# Altium schematic sheet STM32H750XB_POWER.SchDoc
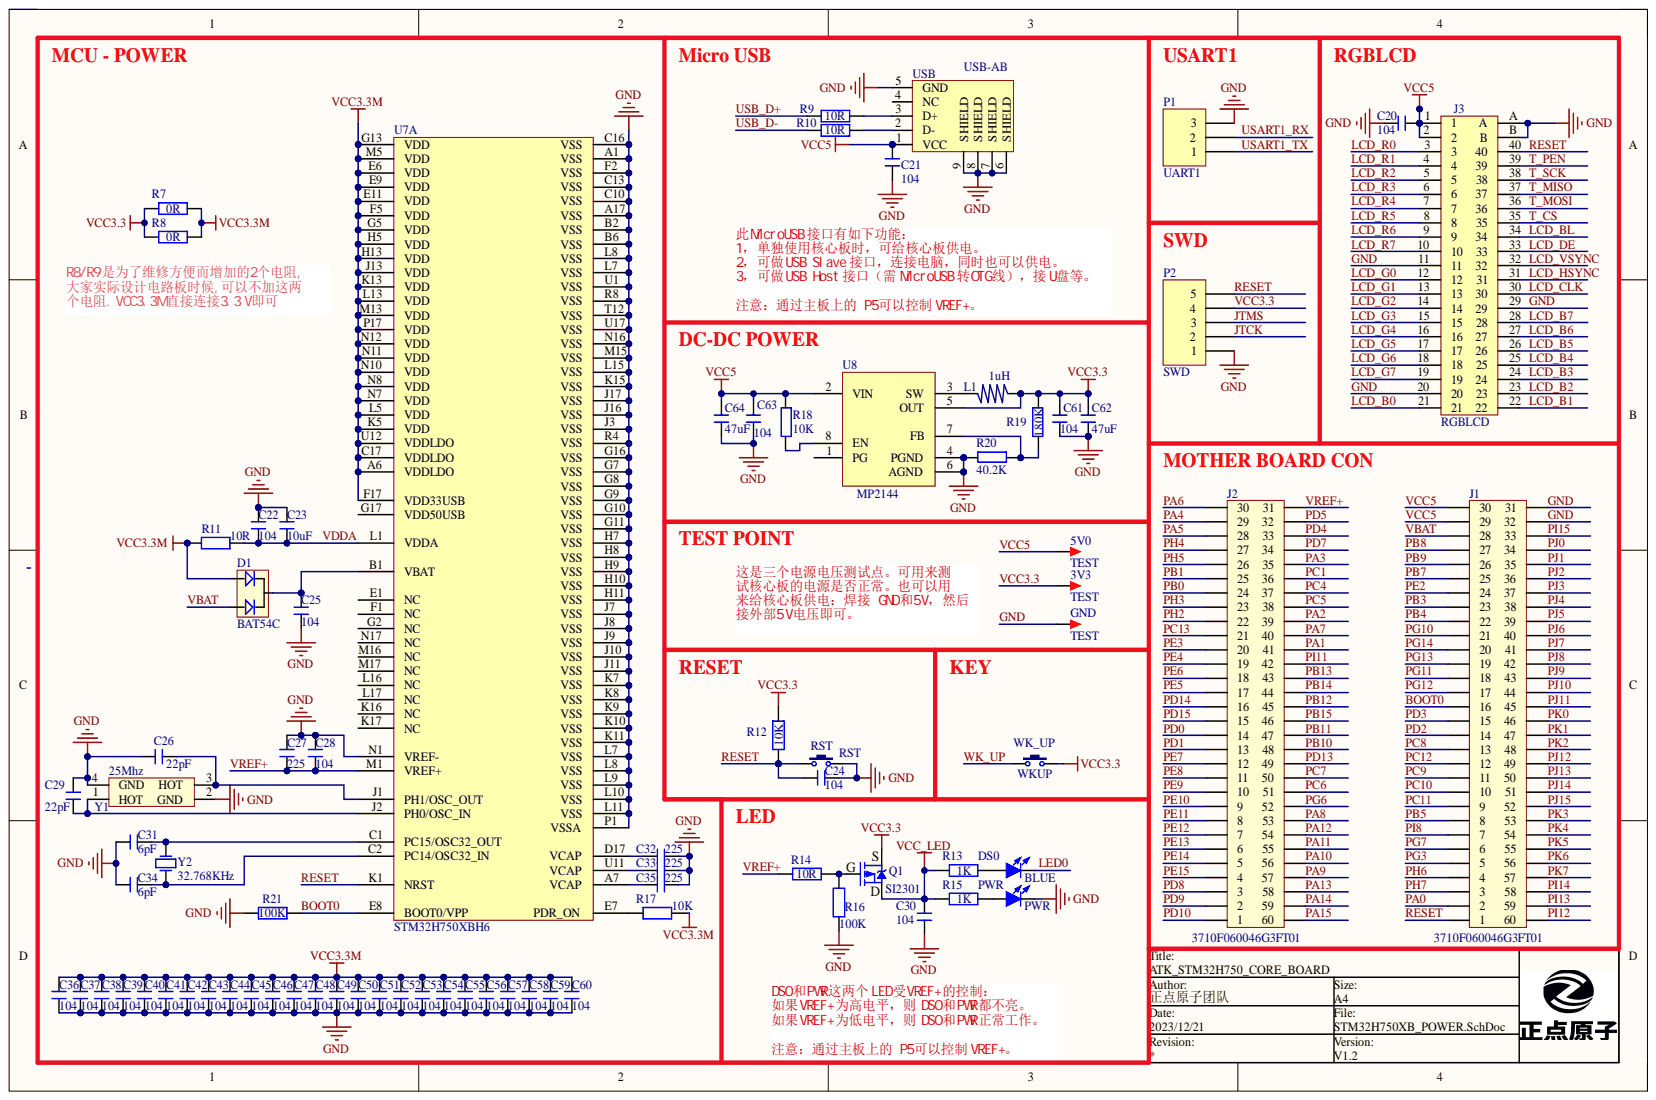
[diagram p0: STM32H750XB_POWER.SchDoc]

## Parts
3V3  TEST  [TEST 1]
5V0  TEST  [TEST 1]
C20  104  [C 0402]
C21  104  [C 0402]
C22  104  [C 0402]
C23  10uF  [C 0603]
C24  104  [C 0402]
C25  104  [C 0402]
C26  22pF  [C 0402]
C27  225  [C 0402]
C28  104  [C 0402]
C29  22pF  [C 0402]
C30  104  [C 0402]
C31  6pF  [C 0402]
C32  225  [C 0402]
C33  225  [C 0402]
C34  6pF  [C 0402]
C35  225  [C 0402]
C36  104  [C 0402]
C37  104  [C 0402]
C38  104  [C 0402]
C39  104  [C 0402]
C40  104  [C 0402]
C41  104  [C 0402]
C42  104  [C 0402]
C43  104  [C 0402]
C44  104  [C 0402]
C45  104  [C 0402]
C46  104  [C 0402]
C47  104  [C 0402]
C48  104  [C 0402]
C49  104  [C 0402]
C50  104  [C 0402]
C51  104  [C 0402]
C52  104  [C 0402]
C53  104  [C 0402]
C54  104  [C 0402]
C55  104  [C 0402]
C56  104  [C 0402]
C57  104  [C 0402]
C58  104  [C 0402]
C59  104  [C 0402]
C60  104  [C 0402]
C61  104  [C 0402]
C62  47uF  [C 0805]
C63  104  [C 0402]
C64  47uF  [C 0805]
D1  BAT54C  [SOT23-3L]
DS0  BLUE  [LED 0603B]
GND  TEST  [TEST 1]
J1  3710F060046G3FT01  [3710F060046G3FT01]
J2  3710F060046G3FT01  [3710F060046G3FT01]
J3  RGBLCD  [FPC0.5-TOP40P]
L1  1uH  [NR3015]
P1  UART1  [HDR1X3]
P2  SWD  [HDR1X5]
PWR  PWR  [LED 0603B]
Q1  SI2301  [SOT23-3N]
R7  0R  [R 0402]
R8  0R  [R 0402]
R9  10R  [R 0402]
R10  10R  [R 0402]
R11  10R  [R 0402]
R12  10K  [R 0402]
R13  1K  [R 0402]
R14  10R  [R 0402]
R15  1K  [R 0402]
R16  100K  [R 0402]
R17  10K  [R 0402]
R18  10K  [R 0402]
R19  180K  [R 0402]
R20  40.2K  [R 0402]
R21  100K  [R 0402]
RST  RST  [KEY-SOP324225]
U7  STM32H750XBH6  [BGA240+25]  (part A)
U8  MP2144  [SOT23-8L]
USB  USB-AB  [USB_AB - 5S - B]
WKUP  WK_UP  [KEY-SOP324225]
Y1  25Mhz  [XTAL-3225]
Y2  32.768KHz  [XTAL-3215]

## Nets
VCC3.3M: C36.2 C37.2 C38.2 C39.2 C40.2 C41.2 C42.2 C43.2 C44.2 C45.2 C46.2 C47.2 C48.2 C49.2 C50.2 C51.2 C52.2 C53.2 C54.2 C55.2 C56.2 C57.2 C58.2 C59.2 C60.2 D1.1 R7.2 R8.2 R11.1 R17.2 U7.A6(VDDLDO) U7.C17(VDDLDO) U7.E6(VDD) U7.E9(VDD) U7.E11(VDD) U7.F5(VDD) U7.F17(VDD33USB) U7.G5(VDD) U7.G13(VDD) U7.H5(VDD) U7.H13(VDD) U7.J13(VDD) U7.K5(VDD) U7.K13(VDD) U7.L5(VDD) U7.L13(VDD) U7.M5(VDD) U7.M13(VDD) U7.N7(VDD) U7.N8(VDD) U7.N10(VDD) U7.N11(VDD) U7.N12(VDD) U7.P17(VDD) U7.U12(VDDLDO)
VCC_LED: C30.2 Q1.3 R13.1 R15.2
BOOT0: J1.16 R21.2 U7.E8(BOOT0/VPP)
GND: C20.2 C21.2 C22.2 C23.2 C24.2 C25.1 C26.1 C27.2 C28.2 C29.1 C30.1 C31.1 C32.2 C33.2 C34.1 C35.2 C36.1 C37.1 C38.1 C39.1 C40.1 C41.1 C42.1 C43.1 C44.1 C45.1 C46.1 C47.1 C48.1 C49.1 C50.1 C51.1 C52.1 C53.1 C54.1 C55.1 C56.1 C57.1 C58.1 C59.1 C60.1 C61.1 C62.1 C63.1 C64.1 GND.1 J1.31 J1.32 J3.11 J3.20 J3.29 J3.A J3.B P1.3 P2.1 PWR.2 R16.1 R20.2 R21.1 RST.2 U7.A1(VSS) U7.A17(VSS) U7.B2(VSS) U7.B6(VSS) U7.C10(VSS) U7.C13(VSS) U7.C16(VSS) U7.F2(VSS) U7.G7(VSS) U7.G8(VSS) U7.G9(VSS) U7.G10(VSS) U7.G11(VSS) U7.G16(VSS) U7.H7(VSS) U7.H8(VSS) U7.H9(VSS) U7.H10(VSS) U7.H11(VSS) U7.J3(VSS) U7.J7(VSS) U7.J8(VSS) U7.J9(VSS) U7.J10(VSS) U7.J11(VSS) U7.J16(VSS) U7.J17(VSS) U7.K7(VSS) U7.K8(VSS) U7.K9(VSS) U7.K10(VSS) U7.K11(VSS) U7.K15(VSS) U7.L7(VSS) U7.L8(VSS) U7.L9(VSS) U7.L10(VSS) U7.L11(VSS) U7.L15(VSS) U7.M15(VSS) U7.N1(VREF-) U7.N16(VSS) U7.P1(VSSA) U7.R4(VSS) U7.R8(VSS) U7.T12(VSS) U7.U1(VSS) U7.U17(VSS) U8.4(PGND) U8.6(AGND) USB.5(GND) USB.6(SHIELD) USB.7(SHIELD) USB.8(SHIELD) USB.9(SHIELD) Y1.2(GND) Y1.4(GND)
JTCK: P2.2
JTMS: P2.3
LCD_B0: J3.21
LCD_B1: J3.22
LCD_B2: J3.23
LCD_B3: J3.24
LCD_B4: J3.25
LCD_B5: J3.26
LCD_B6: J3.27
LCD_B7: J3.28
LCD_BL: J3.34
LCD_CLK: J3.30
LCD_DE: J3.33
LCD_G0: J3.12
LCD_G1: J3.13
LCD_G2: J3.14
LCD_G3: J3.15
LCD_G4: J3.16
LCD_G5: J3.17
LCD_G6: J3.18
LCD_G7: J3.19
LCD_HSYNC: J3.31
LCD_R0: J3.3
LCD_R1: J3.4
LCD_R2: J3.5
LCD_R3: J3.6
LCD_R4: J3.7
LCD_R5: J3.8
LCD_R6: J3.9
LCD_R7: J3.10
LCD_VSYNC: J3.32
LED0: DS0.2
PA0: J1.2
PA1: J2.41
PA2: J2.39
PA3: J2.35
PA4: J2.29
PA5: J2.28
PA6: J2.30
PA7: J2.40
PA8: J2.53
PA9: J2.57
PA10: J2.56
PA11: J2.55
PA12: J2.54
PA13: J2.58
PA14: J2.59
PA15: J2.60
PB0: J2.24
PB1: J2.25
PB3: J1.23
PB4: J1.22
PB5: J1.8
PB7: J1.25
PB8: J1.27
PB9: J1.26
PB10: J2.48
PB11: J2.47
PB12: J2.45
PB13: J2.43
PB14: J2.44
PB15: J2.46
PC1: J2.36
PC4: J2.37
PC5: J2.38
PC6: J2.51
PC7: J2.50
PC8: J1.13
PC9: J1.11
PC10: J1.10
PC11: J1.9
PC12: J1.12
PC13: J2.21
PD0: J2.14
PD1: J2.13
PD2: J1.14
PD3: J1.15
PD4: J2.33
PD5: J2.32
PD7: J2.34
PD8: J2.3
PD9: J2.2
PD10: J2.1
PD13: J2.49
PD14: J2.16
PD15: J2.15
PE2: J1.24
PE3: J2.20
PE4: J2.19
PE5: J2.17
PE6: J2.18
PE7: J2.12
PE8: J2.11
PE9: J2.10
PE10: J2.9
PE11: J2.8
PE12: J2.7
PE13: J2.6
PE14: J2.5
PE15: J2.4
PG3: J1.5
PG6: J2.52
PG7: J1.6
PG10: J1.21
PG11: J1.18
PG12: J1.17
PG13: J1.19
PG14: J1.20
PH2: J2.22
PH3: J2.23
PH4: J2.27
PH5: J2.26
PH6: J1.4
PH7: J1.3
PI8: J1.7
PI11: J2.42
PI12: J1.60
PI13: J1.59
PI14: J1.58
PI15: J1.33
PJ0: J1.34
PJ1: J1.35
PJ2: J1.36
PJ3: J1.37
PJ4: J1.38
PJ5: J1.39
PJ6: J1.40
PJ7: J1.41
PJ8: J1.42
PJ9: J1.43
PJ10: J1.44
PJ11: J1.45
PJ12: J1.49
PJ13: J1.50
PJ14: J1.51
PJ15: J1.52
PK0: J1.46
PK1: J1.47
PK2: J1.48
PK3: J1.53
PK4: J1.54
PK5: J1.55
PK6: J1.56
PK7: J1.57
RESET: C24.1 J1.1 J3.40 P2.5 R12.1 RST.1 U7.K1(NRST)
T_CS: J3.35
T_MISO: J3.37
T_MOSI: J3.36
T_PEN: J3.39
T_SCK: J3.38
USART1_RX: P1.2
USART1_TX: P1.1
USB_D+: R9.1
USB_D-: R10.1
VBAT: D1.2 J1.28
VCC3.3: 3V3.1 C61.2 C62.2 L1.1 P2.4 Q1.2 R7.1 R8.1 R12.2 R19.1 U8.5(OUT) WKUP.2
VCC5: 5V0.1 C20.1 C21.1 C63.2 C64.2 J1.29 J1.30 J3.1 J3.2 R18.2 U8.2(VIN) USB.1(VCC)
VDDA: C22.1 C23.1 R11.2 U7.L1(VDDA)
VREF+: C27.1 C28.1 J2.31 R14.1 U7.M1(VREF+)
WK_UP: WKUP.1
NetC25_2: C25.2 D1.3 U7.B1(VBAT)
NetC26_2: C26.2 U7.J1(PH1/OSC_OUT) Y1.3(HOT)
NetC29_2: C29.2 U7.J2(PH0/OSC_IN) Y1.1(HOT)
NetC31_2: C31.2 U7.C1(PC15/OSC32_OUT) Y2.1
NetC32_1: C32.1 U7.D17(VCAP)
NetC33_1: C33.1 U7.U11(VCAP)
NetC34_2: C34.2 U7.C2(PC14/OSC32_IN) Y2.2
NetC35_1: C35.1 U7.A7(VCAP)
NetDS0_1: DS0.1 R13.2
NetL1_2: L1.2 U8.3(SW)
NetPWR_1: PWR.1 R15.1
NetQ1_1: Q1.1 R14.2 R16.2
NetR9_2: R9.2 USB.3(D+)
NetR10_2: R10.2 USB.2(D-)
NetR17_1: R17.1 U7.E7(PDR_ON)
NetR18_1: R18.1 U8.8(EN)
NetR19_2: R19.2 R20.1 U8.7(FB)

Not connected: U7 E1(NC) F1(NC) G2(NC) G17(VDD50USB) K16(NC) K17(NC) L16(NC) L17(NC) M16(NC) M17(NC) N17(NC); U8 1(PG); USB 4(NC)

## Library data of the parts
3V3 5V0 GND = TEST: 测试点; 版权归属: 源创客; BOM名称: 测试点; Component Kind: Standard; 学习资源: www.ooocke.com; Library Name: Lycreturn.IntLib
C20-C64 = C: 无极性贴片电容; 版权归属: 源创客; BOM名称: 无极性贴片电容; Component Kind: Standard; 学习资源: www.ooocke.com
  C20-C60 C63: Library Name: 电阻-电容-电感.SchLib
  C61 C62 C64: Library Name: Lycreturn.IntLib
D1 = BAT54C: 双肖特基二极管; Component Kind: Standard; Library Name: ALIENTEK_COMPONENT.IntLib
DS0 PWR = LED-SMD: 贴片LED; 版权归属: 源创客; BOM名称: 贴片LED; Component Kind: Standard; 学习资源: www.ooocke.com; Library Name: Lycreturn.IntLib
J1 J2 = 3710F: Component Kind: Standard; Description: 3710F060046G3FT01; Library Name: ALIENTEK_COMPONENT.IntLib
J3 = FPC-40: FPC-40P-0.5mm; Component Kind: Standard; Library Name: STM32H743_CORE.IntLib
L1 = L: Component Kind: Standard; Library Name: MiniFly .IntLib
P1 = Header 3: Header, 3-Pin; Component Kind: Standard; LatestRevisionDate: 17-Jul-2002; LatestRevisionNote: Re-released for DXP Platform.; Library Name: Miscellaneous Connectors.IntLib; Signal Integrity: Connector; Publisher: Altium Limited
P2 = Header 5: Header, 5-Pin; Component Kind: Standard; LatestRevisionDate: 17-Jul-2002; LatestRevisionNote: Re-released for DXP Platform.; Library Name: Miscellaneous Connectors.IntLib; Signal Integrity: Connector; Publisher: Altium Limited
Q1 = SI2301: P沟道场效应管; 版权归属: 源创客; BOM名称: P沟道场效应管; Component Kind: Standard; 学习资源: www.ooocke.com; Library Name: Lycreturn.IntLib
R7-R17 R21 = R: 贴片电阻; 版权归属: 源创客; BOM名称: 贴片电阻; Component Kind: Standard; Library Name: 电阻-电容-电感.SchLib; 学习资源: www.ooocke.com
R18-R20 = R: Component Kind: Standard; Library Name: 电阻-电容-电感.SchLib
RST WKUP = KEY: 按键; BOM名称: 3x6轻触开关; 版权归属: 源创客; Component Kind: Standard; 学习资源: www.ooocke.com; Library Name: Lycreturn.IntLib
U7 = STM32H750XB: Component Kind: Standard; Library Name: ALIENTEK_COMPONENT.IntLib
USB = USB-AB: MicroUSB; Component Kind: Standard; Library Name: ALIENTEK_COMPONENT.IntLib
Y1 = XTAL_3225: 3225贴片无源晶振; Component Kind: Standard; Library Name: ALIENTEK_COMPONENT.IntLib
Y2 = XTAL: 普通晶振; Component Kind: Standard; LatestRevisionDate: 17-Jul-2002; LatestRevisionNote: Re-released for DXP Platform.; Library Name: ALIENTEK_COMPONENT.IntLib; Published: 8-Jun-2000; Publisher: Altium Limited
U8 = MP2144: Component Kind: Standard; Library Name: LDO和DCDC.SchLib
